# Altium schematic sheet MCU.SchDoc
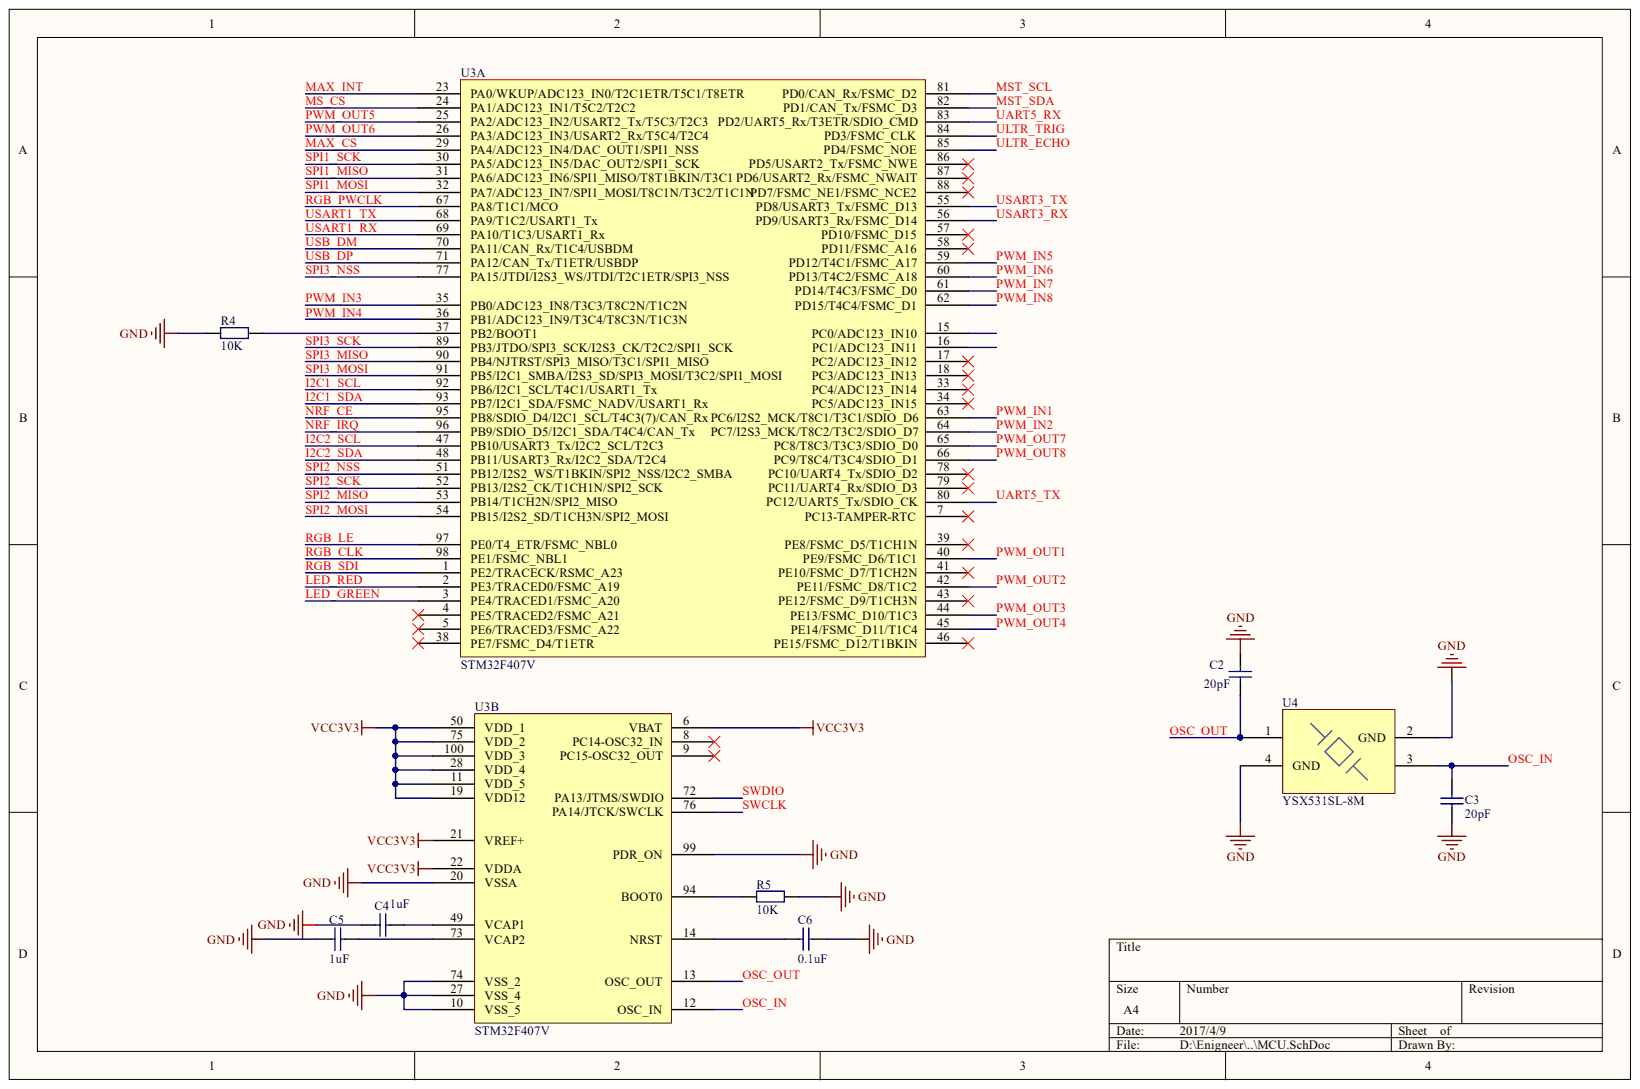
[diagram p0: MCU.SchDoc]

## Parts
C2  value 20pF  [0603C]  (hidden comment: Cap)
C3  value 20pF  [0603C]  (hidden comment: Cap)
C4  value 1uF  [0603C]  (hidden comment: Cap)
C5  value 1uF  [0603C]  (hidden comment: Cap)
C6  value 0.1uF  [0603C]  (hidden comment: Cap)
R4  value 10K  [0603R]  (hidden comment: Res2)
R5  value 10K  [0603R]  (hidden comment: Res2)
U3  STM32F407V  [LQFP-100_M]  (parts A,B)
U4  YSX531SL-8M  [YSX531SL]

## Nets
GND: C2.2 C3.1 C4.2 C5.2 C6.1 R4.2 R5.1 U3.10(VSS_5) U3.20(VSSA) U3.27(VSS_4) U3.74(VSS_2) U3.99(PDR_ON) U4.2(GND) U4.4(GND)
VCC3V3: U3.6(VBAT) U3.11(VDD_5) U3.19(VDD12) U3.21(VREF+) U3.22(VDDA) U3.28(VDD_4) U3.50(VDD_1) U3.75(VDD_2) U3.100(VDD_3)
I2C1_SCL: U3.92(PB6/I2C1_SCL/T4C1/USART1_Tx)
I2C1_SDA: U3.93(PB7/I2C1_SDA/FSMC_NADV/USART1_Rx)
I2C2_SCL: U3.47(PB10/USART3_Tx/I2C2_SCL/T2C3)
I2C2_SDA: U3.48(PB11/USART3_Rx/I2C2_SDA/T2C4)
LED_GREEN: U3.3(PE4/TRACED1/FSMC_A20)
LED_RED: U3.2(PE3/TRACED0/FSMC_A19)
MAX_CS: U3.29(PA4/ADC123_IN4/DAC_OUT1/SPI1_NSS)
MAX_INT: U3.23(PA0/WKUP/ADC123_IN0/T2C1ETR/T5C1/T8ETR)
MS_CS: U3.24(PA1/ADC123_IN1/T5C2/T2C2)
MST_SCL: U3.81(PD0/CAN_Rx/FSMC_D2)
MST_SDA: U3.82(PD1/CAN_Tx/FSMC_D3)
NRF_CE: U3.95(PB8/SDIO_D4/I2C1_SCL/T4C3(7)/CAN_Rx)
NRF_IRQ: U3.96(PB9/SDIO_D5/I2C1_SDA/T4C4/CAN_Tx)
OSC_IN: C3.2 U3.12(OSC_IN) U4.3
OSC_OUT: C2.1 U3.13(OSC_OUT) U4.1
PWM_IN1: U3.63(PC6/I2S2_MCK/T8C1/T3C1/SDIO_D6)
PWM_IN2: U3.64(PC7/I2S3_MCK/T8C2/T3C2/SDIO_D7)
PWM_IN3: U3.35(PB0/ADC123_IN8/T3C3/T8C2N/T1C2N)
PWM_IN4: U3.36(PB1/ADC123_IN9/T3C4/T8C3N/T1C3N)
PWM_IN5: U3.59(PD12/T4C1/FSMC_A17)
PWM_IN6: U3.60(PD13/T4C2/FSMC_A18)
PWM_IN7: U3.61(PD14/T4C3/FSMC_D0)
PWM_IN8: U3.62(PD15/T4C4/FSMC_D1)
PWM_OUT1: U3.40(PE9/FSMC_D6/T1C1)
PWM_OUT2: U3.42(PE11/FSMC_D8/T1C2)
PWM_OUT3: U3.44(PE13/FSMC_D10/T1C3)
PWM_OUT4: U3.45(PE14/FSMC_D11/T1C4)
PWM_OUT5: U3.25(PA2/ADC123_IN2/USART2_Tx/T5C3/T2C3)
PWM_OUT6: U3.26(PA3/ADC123_IN3/USART2_Rx/T5C4/T2C4)
PWM_OUT7: U3.65(PC8/T8C3/T3C3/SDIO_D0)
PWM_OUT8: U3.66(PC9/T8C4/T3C4/SDIO_D1)
RGB_CLK: U3.98(PE1/FSMC_NBL1)
RGB_LE: U3.97(PE0/T4_ETR/FSMC_NBL0)
RGB_PWCLK: U3.67(PA8/T1C1/MCO)
RGB_SDI: U3.1(PE2/TRACECK/RSMC_A23)
SPI1_MISO: U3.31(PA6/ADC123_IN6/SPI1_MISO/T8T1BKIN/T3C1)
SPI1_MOSI: U3.32(PA7/ADC123_IN7/SPI1_MOSI/T8C1N/T3C2/T1C1N)
SPI1_SCK: U3.30(PA5/ADC123_IN5/DAC_OUT2/SPI1_SCK)
SPI2_MISO: U3.53(PB14/T1CH2N/SPI2_MISO)
SPI2_MOSI: U3.54(PB15/I2S2_SD/T1CH3N/SPI2_MOSI)
SPI2_NSS: U3.51(PB12/I2S2_WS/T1BKIN/SPI2_NSS/I2C2_SMBA)
SPI2_SCK: U3.52(PB13/I2S2_CK/T1CH1N/SPI2_SCK)
SPI3_MISO: U3.90(PB4/NJTRST/SPI3_MISO/T3C1/SPI1_MISO)
SPI3_MOSI: U3.91(PB5/I2C1_SMBA/I2S3_SD/SPI3_MOSI/T3C2/SPI1_MOSI)
SPI3_NSS: U3.77(PA15/JTDI/I2S3_WS/JTDI/T2C1ETR/SPI3_NSS)
SPI3_SCK: U3.89(PB3/JTDO/SPI3_SCK/I2S3_CK/T2C2/SPI1_SCK)
SWCLK: U3.76(PA14/JTCK/SWCLK)
SWDIO: U3.72(PA13/JTMS/SWDIO)
UART5_RX: U3.83(PD2/UART5_Rx/T3ETR/SDIO_CMD)
UART5_TX: U3.80(PC12/UART5_Tx/SDIO_CK)
ULTR_ECHO: U3.85(PD4/FSMC_NOE)
ULTR_TRIG: U3.84(PD3/FSMC_CLK)
USART1_RX: U3.69(PA10/T1C3/USART1_Rx)
USART1_TX: U3.68(PA9/T1C2/USART1_Tx)
USART3_RX: U3.56(PD9/USART3_Rx/FSMC_D14)
USART3_TX: U3.55(PD8/USART3_Tx/FSMC_D13)
USB_DM: U3.70(PA11/CAN_Rx/T1C4/USBDM)
USB_DP: U3.71(PA12/CAN_Tx/T1ETR/USBDP)
NetC4_1: C4.1 U3.49(VCAP1)
NetC5_1: C5.1 U3.73(VCAP2)
NetC6_2: C6.2 U3.14(NRST)
NetR4_1: R4.1 U3.37(PB2/BOOT1)
NetR5_2: R5.2 U3.94(BOOT0)

Not connected: U3 4(PE5/TRACED2/FSMC_A21) 5(PE6/TRACED3/FSMC_A22) 7(PC13-TAMPER-RTC) 8(PC14-OSC32_IN) 9(PC15-OSC32_OUT) 15(PC0/ADC123_IN10) 16(PC1/ADC123_IN11) 17(PC2/ADC123_IN12) 18(PC3/ADC123_IN13) 33(PC4/ADC123_IN14) 34(PC5/ADC123_IN15) 38(PE7/FSMC_D4/T1ETR) 39(PE8/FSMC_D5/T1CH1N) 41(PE10/FSMC_D7/T1CH2N) 43(PE12/FSMC_D9/T1CH3N) 46(PE15/FSMC_D12/T1BKIN) 57(PD10/FSMC_D15) 58(PD11/FSMC_A16) 78(PC10/UART4_Tx/SDIO_D2) 79(PC11/UART4_Rx/SDIO_D3) 86(PD5/USART2_Tx/FSMC_NWE) 87(PD6/USART2_Rx/FSMC_NWAIT) 88(PD7/FSMC_NE1/FSMC_NCE2)

## Library data of the parts
C2-C6 = Cap: Capacitor; Component Kind: Standard; LatestRevisionDate: 17-Jul-2002; LatestRevisionNote: Re-released for DXP Platform.; Library Name: Miscellaneous Devices.IntLib; PackageDescription: Radial Cap, Thru-Hole; 2 Leads; 0.3 in Pin Spacing; PackageReference: RAD-0.3; Published: 8-Jun-2000; Signal Integrity: Cap; Simulation: CAP; Publisher: Altium Limited
R4 R5 = Res2: Resistor; Component Kind: Standard; LatestRevisionDate: 17-Jul-2002; LatestRevisionNote: Re-released for DXP Platform.; Library Name: Miscellaneous Devices.IntLib; PackageDescription: Axial Device, Thru-Hole; 2 Leads; 0.4 in Pin Spacing; PackageReference: AXIAL-0.4; Published: 8-Jun-2000; Signal Integrity: Res; Simulation: RESISTOR; Publisher: Altium Limited
U3 = STM32F407V: STM32单片机100引脚; Component Kind: Standard; Library Name: pxLib.SchLib
U4 = YSX531SL: Component Kind: Standard; Library Name: pxLib.SchLib
